# Altium schematic sheet Sheet1.SchDoc
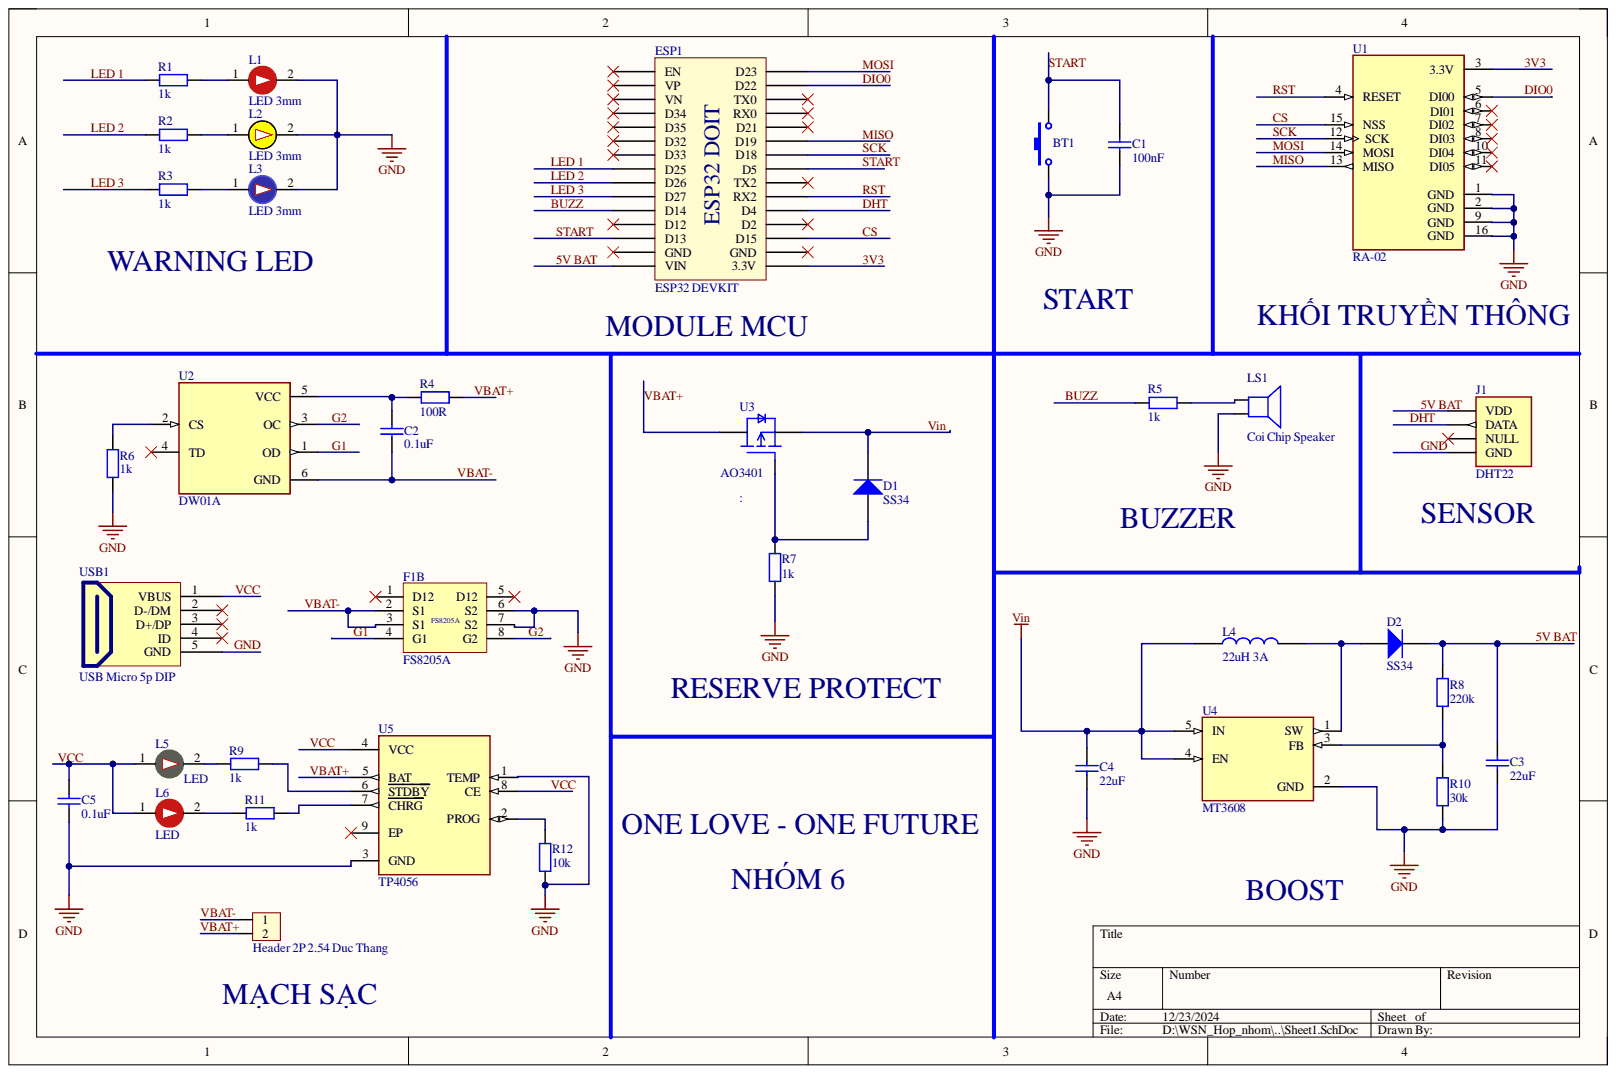
[diagram p0: Sheet1.SchDoc]

## Parts
BT1  Nut Nhan 2 Chan SMD 3x6x2.5  [Nut Nhan 2 Chan SMD 3x6x2.5]  (value not drawn)
C1  100nF  [tu 0805]
C2  0.1uF  [tu 0805]
C3  22uF  [tu 0805]
C4  22uF  [tu 0805]
C5  0.1uF  [tu 0805]
D1  SS34  [DIODE SMA]
D2  SS34  [DIODE SMA]
ESP1  ESP32 DEVKIT  [ESP32 WROOM 32 KIT]
F1  FS8205A  [SOP65P640X120-8N]  (part B)
H1  Header 2P 2.54 Duc Thang  [XH2.54M-2]
J1  DHT22  [DHT22]
L1  LED 3mm  [LED-3MM-RED]
L2  LED 3mm  [LED-3MM-YELLOW]
L3  LED 3mm  [LED-3MM-BLUE]
L4  22uH 3A  [CUON CAM 100uH3A]
L5  LED  [LED-3MM-GREEN]
L6  LED  [LED-3MM-RED]
LS1  Coi Chip Speaker  [Coi Chip Speacker]
R1  1k  [tro smd 0805]
R2  1k  [tro smd 0805]
R3  1k  [tro smd 0805]
R4  100R  [tro smd 0805]
R5  1k  [tro smd 0805]
R6  1k  [tro smd 0805]
R7  1k  [tro smd 0805]
R8  220k  [tro smd 0805]
R9  1k  [tro smd 0805]
R10  30k  [tro smd 0805]
R11  1k  [tro smd 0805]
R12  10k  [tro smd 0805]
U1  RA-02  [RA-02]
U2  DW01A  [SOT95P280X145-6N]
U3  AO3401  [SOT23-3]
U4  MT3608  [SOT95P280X145-6N]
U5  TP4056  [SOP127P600X175-9N]
USB1  USB Micro 5p DIP  [USB Micro 5p DIP]

## Nets
3V3: ESP1.16 U1.3
5V BAT: C3.1 D2.2 ESP1.15 J1.VDD R8.2
BUZZ: ESP1.11 R5.1
CS: ESP1.18 U1.15
DHT: ESP1.20 J1.DATA
DIO0: ESP1.29 U1.5
G1: F1.4 U2.1
G2: F1.8 U2.3
GND: BT1.1 C1.2 C3.2 C4.2 C5.2 F1.6 F1.7 J1.GND L1.2 L2.2 L3.2 LS1.2 R6.1 R7.1 R10.1 R12.1 U1.1 U1.2 U1.9 U1.16 U4.2 U5.1 U5.3 USB1.5
LED 1: ESP1.8 R1.1
LED 2: ESP1.9 R2.1
LED 3: ESP1.10 R3.1
MISO: ESP1.25 U1.13
MOSI: ESP1.30 U1.14
RST: ESP1.21 U1.4
SCK: ESP1.24 U1.12
START: BT1.2 C1.1 ESP1.13 ESP1.23
VBAT+: H1.2 R4.2 U3.3 U5.5
VBAT-: C2.2 F1.2 F1.3 H1.1 U2.6
VCC: C5.1 L5.1 L6.1 U5.4 U5.8 USB1.1
Vin: C4.1 D1.2 L4.1 U3.2 U4.4 U4.5
NetC2_1: C2.1 R4.1 U2.5
NetD1_1: D1.1 R7.2 U3.1
NetD2_1: D2.1 L4.2 U4.1
NetL1_1: L1.1 R1.2
NetL2_1: L2.1 R2.2
NetL3_1: L3.1 R3.2
NetL5_2: L5.2 R9.1
NetL6_2: L6.2 R11.1
NetLS1_1: LS1.1 R5.2
NetR6_2: R6.2 U2.2
NetR8_1: R8.1 R10.2 U4.3
NetR9_2: R9.2 U5.6
NetR11_2: R11.2 U5.7
NetR12_2: R12.2 U5.2

Not connected: ESP1 1 2 3 4 5 6 7 12 14 17 19 22 26 27 28; F1 1 5; J1 NC; U1 6 7 8 10 11; U2 4; U5 9; USB1 2 3 4

## Library data of the parts
BT1 = Nut Nhan 2 Chan SMD 3x6x2.5: Ma_Hang: MH001036; Ten: Nút Nhấn 2 Chân 3x6x2.5MM SMD; Component Kind: Standard; Description: Nut Nhan 2 Chan SMD 3x6x2.5; Library Name: Altium_Lib_by_KhueNguyenCreator.IntLib
C1 = Tu 0805 220nF 50V 10%: Dán; Don_Vi: Con; Ma_Hang: MH008099; So_Chan: 2; Ten_Linh_Kien: Tụ 0805 220nF 50V 10%; Chung_Loai: Dán; Component Kind: Standard; Library Name: Altium_Lib_by_KhueNguyenCreator.IntLib
C2 C5 = Tu 0805 10pF 50V 10%: Dán; Don_Vi: Con; Ma_Hang: MH004554; So_Chan: 2; Ten_Linh_Kien: Tụ 0805 10P 10% 50V; Chung_Loai: Dán; Component Kind: Standard; Library Name: Altium_Lib_by_KhueNguyenCreator.IntLib
C3 C4 = Tu 0805 22nF 50V 20%: Dán; Don_Vi: Con; Ma_Hang: MH007857; So_Chan: 2; Ten_Linh_Kien: Tụ 0805 22nF 50V 20%; Chung_Loai: Dán; Component Kind: Standard; Library Name: Altium_Lib_by_KhueNguyenCreator.IntLib
D1 D2 = Diode SS34 ( 1N5822 ): Dán; Don_Vi: Con; Ma_Hang: MH002104; Ten_Linh_Kien: Diode SS34 3A 40V SMA ( 1N5822 SS34A); Chung_Loai: Dán; Component Kind: Standard; Library Name: Altium_Lib_by_KhueNguyenCreator.IntLib
ESP1 = ESP32 WROOM 32 KIT: Component Kind: Standard; Library Name: Altium_Lib_by_KhueNguyenCreator.IntLib
H1 = Header 2P 2.54 Duc Thang: Cắm; Don_Vi: Con; Ma_Hang: MH001283; Ten_Linh_Kien: Header 2P XH2.54-2P Đực Thẳng; Chung_Loai: Cắm; Component Kind: Standard; Library Name: Altium_Lib_by_KhueNguyenCreator.IntLib
J1 = DHT22: Capacitive-type humidity and temperature module/sensor; MF: Aosong Electronics; Check_prices: https://www.snapeda.com/parts/DHT22/Aosong+Electronics/view-part/?ref=eda; Component Kind: Standard; Library Name: DHT22.IntLib; MP: DHT22; Package: Package; SnapEDA_Link: https://www.snapeda.com/parts/DHT22/Aosong+Electronics/view-part/?ref=snap
L1 L6 = LED 3mm Do: Cắm; Don_Vi: Con; Ma_Hang: MH003892; Ten_Linh_Kien: Led 3MM Phủ Đỏ (10c); Chung_Loai: Cắm; Component Kind: Standard; Library Name: Altium_Lib_by_KhueNguyenCreator.IntLib
L2 = LED 3mm Vang: Cắm; Don_Vi: Con; Ma_Hang: MH003893; Ten_Linh_Kien: Led 3MM Phủ Vàng (10c); Chung_Loai: Cắm; Component Kind: Standard; Library Name: Altium_Lib_by_KhueNguyenCreator.IntLib
L3 = LED 3mm Xanh Duong: Cắm; Don_Vi: Con; Ma_Hang: MH009003; Ten_Linh_Kien: LED 3MM Xanh Dương SS (1000c); Chung_Loai: Cắm; Component Kind: Standard; Library Name: Altium_Lib_by_KhueNguyenCreator.IntLib
L4 = CUON CAM 100uH DIP: Dán; Don_Vi: Con; Ma_Hang: MH002209; Ten_Linh_Kien: Cuộn Cảm 100uH 3A 8x10MM; Chung_Loai: Dán; Component Kind: Standard; Library Name: Altium_Lib_by_KhueNguyenCreator.IntLib
L5 = LED 3mm Xanh La: Cắm; Don_Vi: Con; Ma_Hang: MH001054; Ten_Linh_Kien: Led 3MM Phủ Xanh (10c); Chung_Loai: Cắm; Component Kind: Standard; Library Name: Altium_Lib_by_KhueNguyenCreator.IntLib
LS1 = Coi Chip Speaker: Còi chip; Component Kind: Standard; Library Name: Altium_Lib_by_KhueNguyenCreator.IntLib
R1-R3 R5-R7 R9 R11 = Tro 0805 1% 1k: Dán; Don_Vi: Con; Ma_Hang: MH005468; So_Chan: 2; Ten_Linh_Kien: Trở 0805 1% 1k; Chung_Loai: Dán; Component Kind: Standard; Library Name: Altium_Lib_by_KhueNguyenCreator.IntLib
R4 = Tro 0805 5% 100R: Dán; Don_Vi: Con; Ma_Hang: MH005251; So_Chan: 2; Ten_Linh_Kien: Trở 0805 5% 100R; Chung_Loai: Dán; Component Kind: Standard; Library Name: Altium_Lib_by_KhueNguyenCreator.IntLib
R8 = Tro 0805 1% 220k: Dán; Don_Vi: Con; Ma_Hang: MH005484; So_Chan: 2; Ten_Linh_Kien: Trở 0805 1% 220k; Chung_Loai: Dán; Component Kind: Standard; Library Name: Altium_Lib_by_KhueNguyenCreator.IntLib
R10 = Tro 0805 5% 30k: Dán; Don_Vi: Con; Ma_Hang: MH005314; So_Chan: 2; Ten_Linh_Kien: Trở 0805 5% 30k; Chung_Loai: Dán; Component Kind: Standard; Library Name: Altium_Lib_by_KhueNguyenCreator.IntLib
R12 = Tro 0805 1% 10k: Dán; Don_Vi: Con; Ma_Hang: MH005473; So_Chan: 2; Ten_Linh_Kien: Trở 0805 1% 10k; Chung_Loai: Dán; Component Kind: Standard; Library Name: Altium_Lib_by_KhueNguyenCreator.IntLib
U1 = RA-02: General ISM < 1GHz LoRaâ„¢ Transceiver Module 410MHz ~ 525MHz Antenna Not Included, I-PEX Surface Mount; MF: Ai-Thinker; Availability: Not in stock; Check_prices: https://www.snapeda.com/parts/RA-02/AI-Thinker/view-part/?ref=eda; Component Kind: Standard; Library Name: RA-02.IntLib; MP: RA-02; Package: None; Price: None; SnapEDA_Link: https://www.snapeda.com/parts/RA-02/AI-Thinker/view-part/?ref=snap
U2 = DW01A: One Cell Lithium-ion/Polymer Battery Protection IC; MF: Fortune Semiconductor; Check_prices: https://www.snapeda.com/parts/DW01A/Fortune+Semiconductor/view-part/?ref=eda; Component Kind: Standard; Library Name: DW01A.IntLib; MP: DW01A; Package: SOT-23-6 Fortune Semiconductor; SnapEDA_Link: https://www.snapeda.com/parts/DW01A/Fortune+Semiconductor/view-part/?ref=snap
U3 = AO3401: P-Channel 30V 4A (Ta) 1.4W (Ta) Surface Mount SOT-23-3L; MF: Alpha & Omega Semiconductor; Arrow_Electronics-Purchase-URL: https://snapeda.com/shop?store=Arrow+Electronics&id=4795619; Availability: Warning; Component Kind: Standard; Library Name: AO3401.IntLib; MP: AO3401; Package: SOT-23-3 Alpha &amp; Omega Semiconductor; Price: None; SnapEDA_Link: https://www.snapeda.com/parts/AO3401/Alpha%20&%20Omega%20Semiconductor/view-part/4795619/?ref=snap
U4 = MT3608: High Efficiency 1.2MHz2A Step Up Converter; MF: Aerosemi Technology Co., Ltd; Availability: Not in stock; Check_prices: https://www.snapeda.com/parts/MT3608/Aerosemi+Technology+Co.%252C+Ltd/view-part/?ref=eda; Component Kind: Standard; Library Name: MT3608.SchLib; MP: MT3608; Package: Package; Price: None; SnapEDA_Link: https://www.snapeda.com/parts/MT3608/Aerosemi+Technology+Co.%252C+Ltd/view-part/?ref=snap
U5 = TP4056: Complete single cell Li-Ion battery with a constant current / constant voltage linear charger; MF: NanJing Top Power ASIC Corp.; Availability: Not in stock; Check_prices: https://www.snapeda.com/parts/TP4056/NanJing+Top+Power+ASIC+Corp./view-part/?ref=eda; Component Kind: Standard; Library Name: TP4056.IntLib; MP: TP4056; Package: Package; Price: None; SnapEDA_Link: https://www.snapeda.com/parts/TP4056/NanJing+Top+Power+ASIC+Corp./view-part/?ref=snap
USB1 = USB Micro 5p DIP: USB Type B; Ma_Hang: MH005145; Ten: MicroUSB MK5P DIP; Component Kind: Standard; Library Name: Altium_Lib_by_KhueNguyenCreator.IntLib
F1 = FS8205A: Component Kind: Standard; Library Name: FS8205A.SchLib
